# Altium schematic sheet uart_mux.SchDoc(uart_mux)
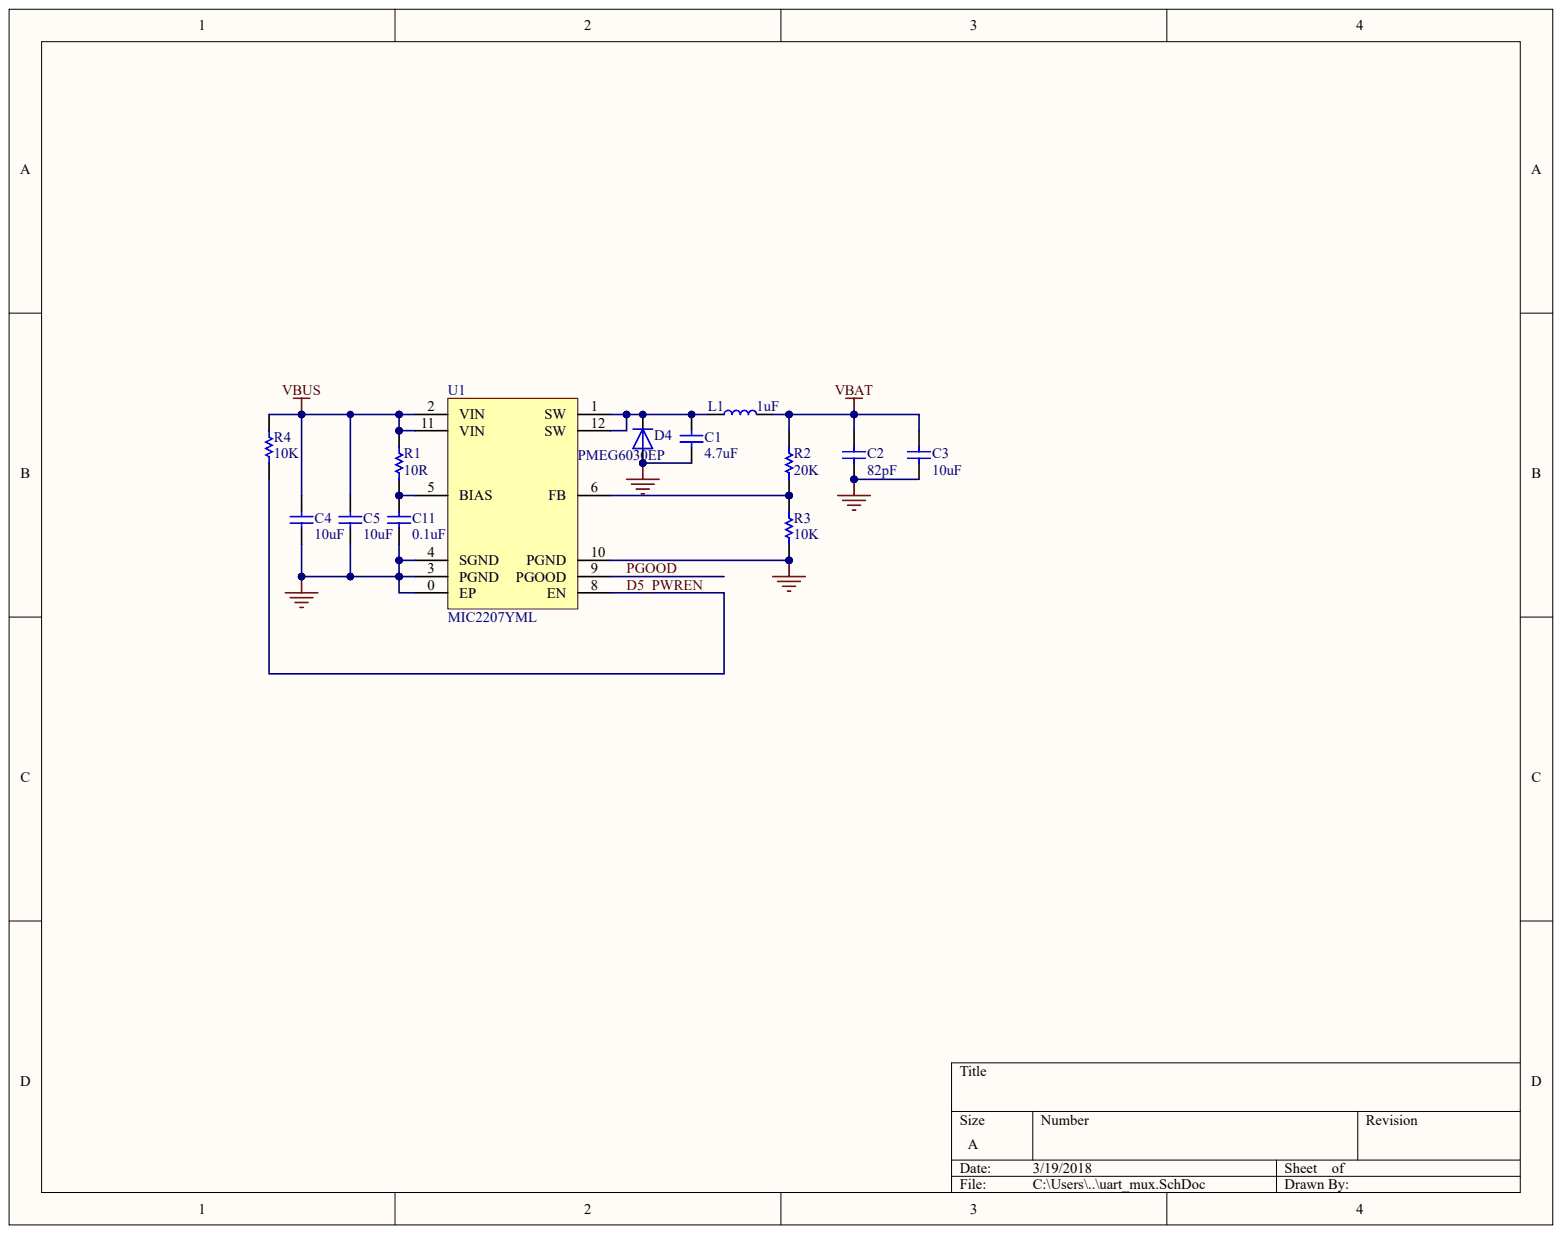
[diagram p0: uart_mux.SchDoc]

## Parts
C1  4.7uF
C2  82pF
C3  10uF
C4  10uF
C5  10uF
C11  0.1uF
D4  PMEG6030EP
L1  1uF
R1  10R
R2  20K
R3  10K
R4  10K
U1  MIC2207YML

## Nets
GND: C1.2 C2.2 C3.2 C4.2 C5.2 C11.2 D4.A R3.2 U1.0(EP) U1.3(PGND) U1.4(SGND) U1.10(PGND)
VBAT: C2.1 C3.1 L1.2 R2.1
VBUS: C4.1 C5.1 R1.1 R4.1 U1.2(VIN) U1.11(VIN)
D5_PWREN: R4.2 U1.8(EN)
PGOOD: U1.9(PGOOD)
NetC1_1: C1.1 D4.K L1.1 U1.1(SW) U1.12(SW)
NetC11_1: C11.1 R1.2 U1.5(BIAS)
NetR2_2: R2.2 R3.1 U1.6(FB)

## Library data of the parts
C1-C5 C11 = (no symbol name): Tolerance: 10%; Voltage Rating: 50V
  C1: Value: 4.7uF
  C2: Value: 82pF
  C3-C5: Value: 10uF
  C11: Value: 0.1uF
D4 = (no symbol name): Manufacturer: NXP; PartNumber: PMEG6030EP
L1 = (no symbol name): PartNumber: SRU5018-1R0Y; Manufacturer: Bourns; Tolerance: 1%; Value: 1uF
R1-R4 = (no symbol name): Tolerance: 1%
  R1: Value: 10R
  R2: Value: 20K
  R3 R4: Value: 10K
U1 = (no symbol name): Manufacturer: Microchip; PartNumber: MIC2207YML
